# Altium schematic sheet 2_MIC.SchDoc
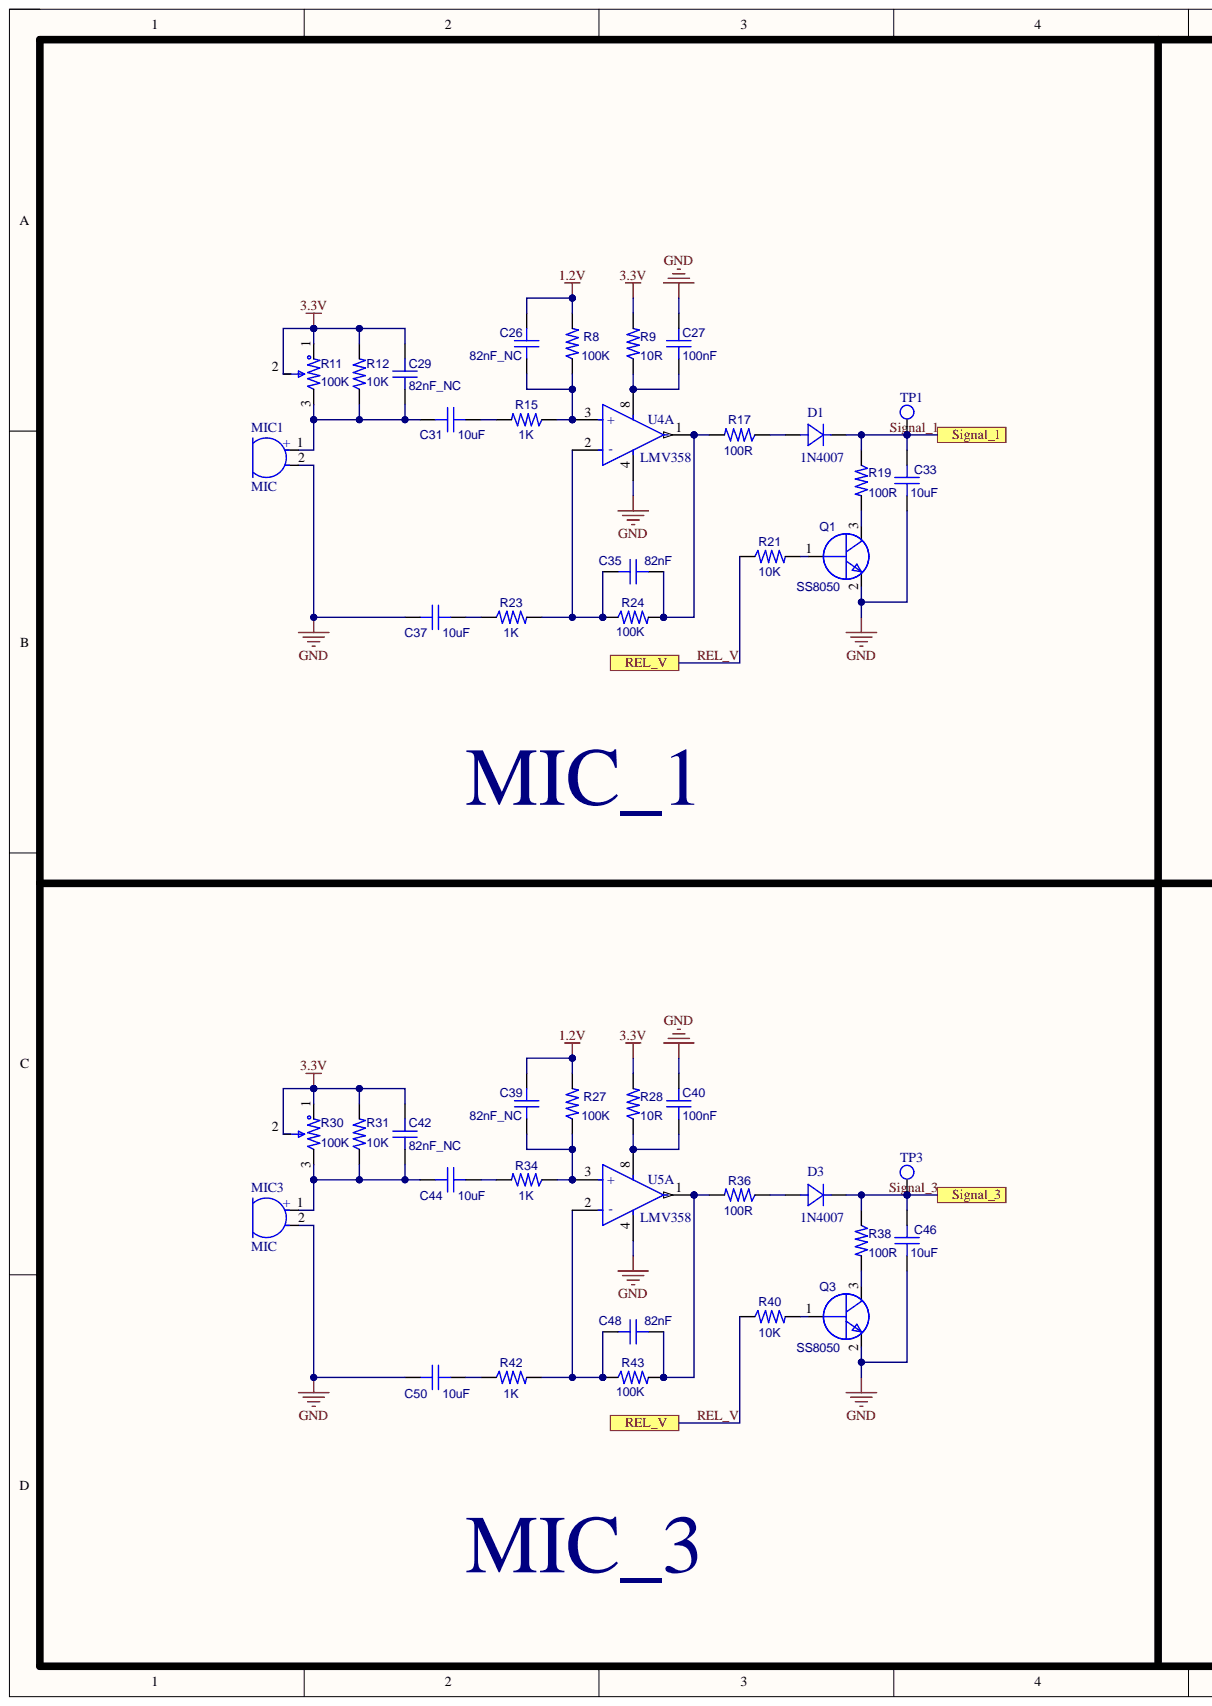
[diagram p0: 2_MIC.SchDoc, left]
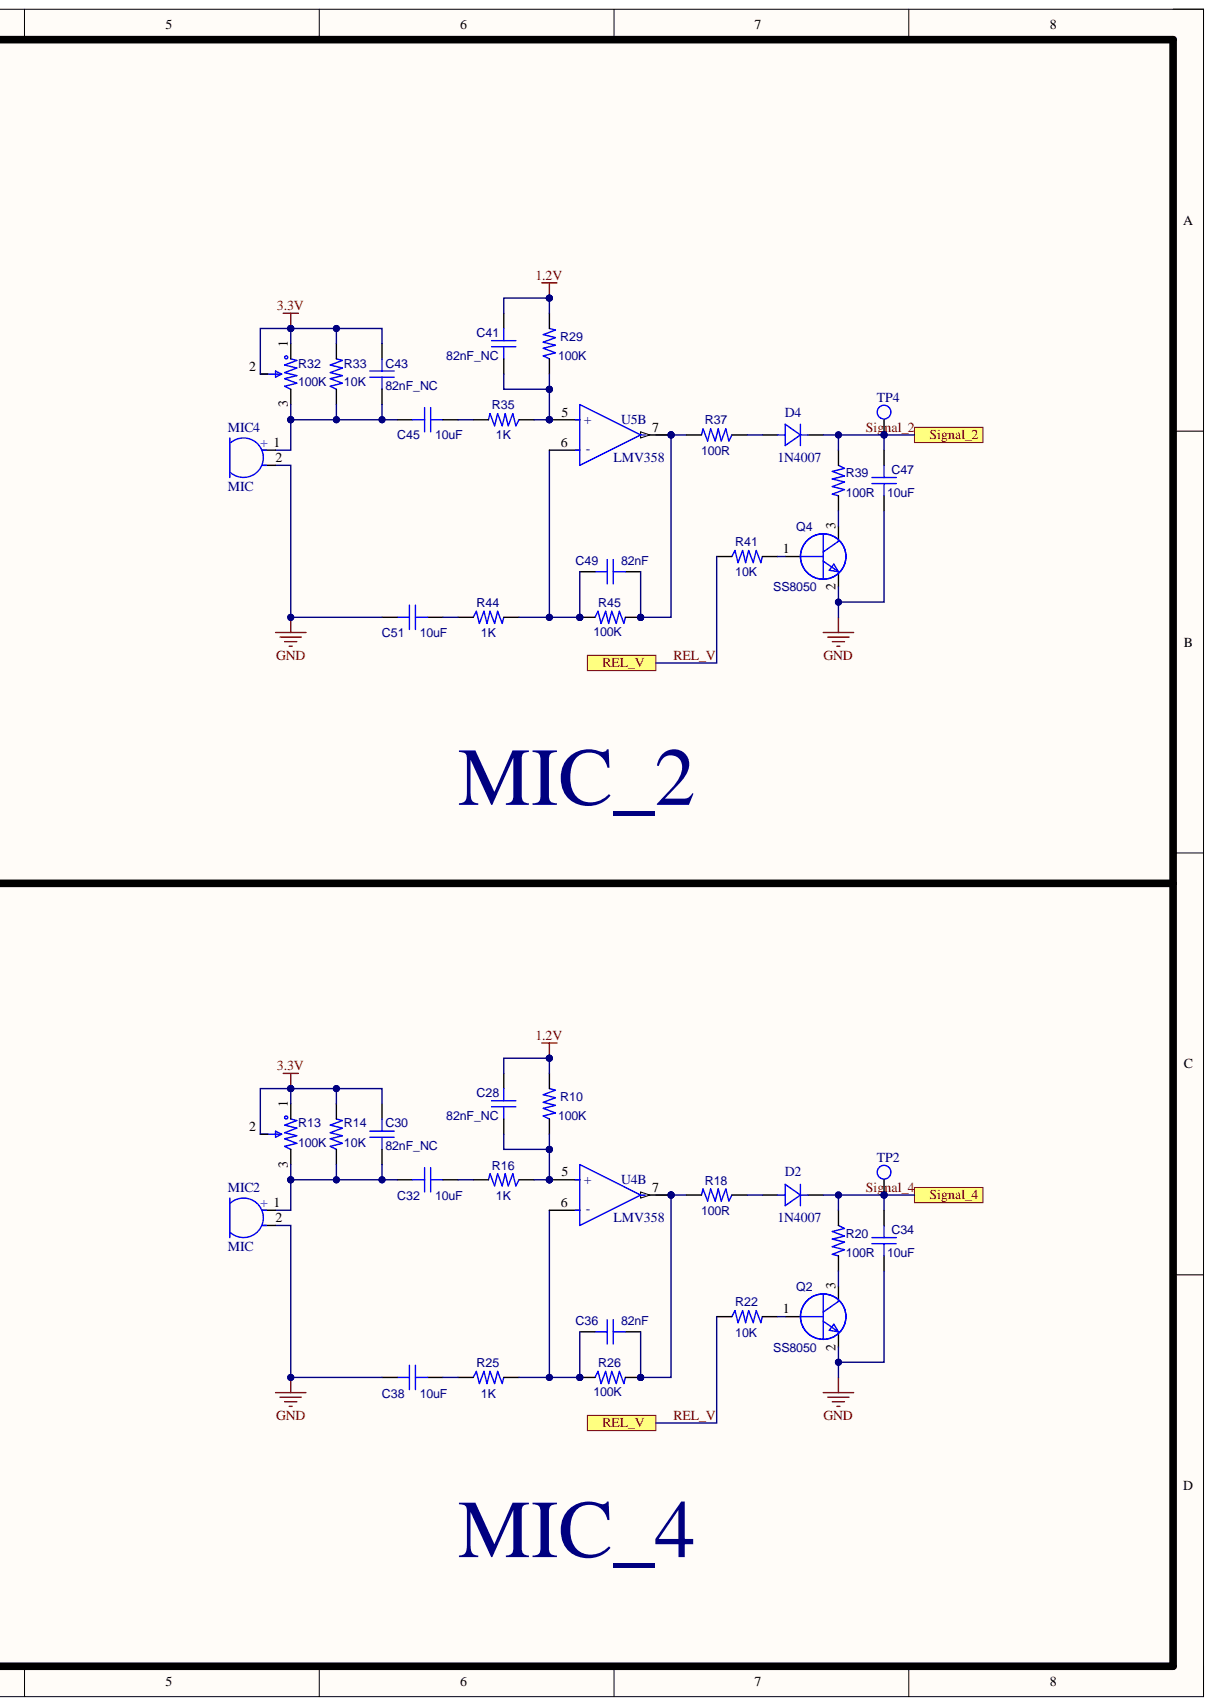
[diagram p1: 2_MIC.SchDoc, right]

## Parts
C26  82nF_NC  [CAP-SMD-0402]
C27  100nF  [CAP-SMD-0402]
C28  82nF_NC  [CAP-SMD-0402]
C29  82nF_NC  [CAP-SMD-0402]
C30  82nF_NC  [CAP-SMD-0402]
C31  10uF  [CAP-SMD-0603]
C32  10uF  [CAP-SMD-0603]
C33  10uF  [CAP-SMD-0603]
C34  10uF  [CAP-SMD-0603]
C35  82nF  [CAP-SMD-0402]
C36  82nF  [CAP-SMD-0402]
C37  10uF  [CAP-SMD-0603]
C38  10uF  [CAP-SMD-0603]
C39  82nF_NC  [CAP-SMD-0402]
C40  100nF  [CAP-SMD-0402]
C41  82nF_NC  [CAP-SMD-0402]
C42  82nF_NC  [CAP-SMD-0402]
C43  82nF_NC  [CAP-SMD-0402]
C44  10uF  [CAP-SMD-0603]
C45  10uF  [CAP-SMD-0603]
C46  10uF  [CAP-SMD-0603]
C47  10uF  [CAP-SMD-0603]
C48  82nF  [CAP-SMD-0402]
C49  82nF  [CAP-SMD-0402]
C50  10uF  [CAP-SMD-0603]
C51  10uF  [CAP-SMD-0603]
D1  1N4007  [SOD-123-P3.4]
D2  1N4007  [SOD-123-P3.4]
D3  1N4007  [SOD-123-P3.4]
D4  1N4007  [SOD-123-P3.4]
MIC1  MIC  [CONN-TH-1X2P-P2.54_2]
MIC2  MIC  [CONN-TH-1X2P-P2.54_2]
MIC3  MIC  [CONN-TH-1X2P-P2.54_2]
MIC4  MIC  [CONN-TH-1X2P-P2.54_2]
Q1  SS8050  [SOT-23-3-LP2.28-P1.91]
Q2  SS8050  [SOT-23-3-LP2.28-P1.91]
Q3  SS8050  [SOT-23-3-LP2.28-P1.91]
Q4  SS8050  [SOT-23-3-LP2.28-P1.91]
R8  100K  [RES-SMD-0402]
R9  10R  [RES-SMD-0402]
R10  100K  [RES-SMD-0402]
R11  100K  [RES-TH-ADJ-3396W]
R12  10K  Resistance (Ohms): 100K  [RES-SMD-0402]
R13  100K  [RES-TH-ADJ-3396W]
R14  10K  Resistance (Ohms): 100K  [RES-SMD-0402]
R15  1K  [RES-SMD-0402]
R16  1K  [RES-SMD-0402]
R17  100R  [RES-SMD-0402]
R18  100R  [RES-SMD-0402]
R19  100R  [RES-SMD-0402]
R20  100R  [RES-SMD-0402]
R21  10K  [RES-SMD-0402]
R22  10K  [RES-SMD-0402]
R23  1K  [RES-SMD-0402]
R24  100K  [RES-SMD-0402]
R25  1K  [RES-SMD-0402]
R26  100K  [RES-SMD-0402]
R27  100K  [RES-SMD-0402]
R28  10R  [RES-SMD-0402]
R29  100K  [RES-SMD-0402]
R30  100K  [RES-TH-ADJ-3396W]
R31  10K  Resistance (Ohms): 100K  [RES-SMD-0402]
R32  100K  [RES-TH-ADJ-3396W]
R33  10K  Resistance (Ohms): 100K  [RES-SMD-0402]
R34  1K  [RES-SMD-0402]
R35  1K  [RES-SMD-0402]
R36  100R  [RES-SMD-0402]
R37  100R  [RES-SMD-0402]
R38  100R  [RES-SMD-0402]
R39  100R  [RES-SMD-0402]
R40  10K  [RES-SMD-0402]
R41  10K  [RES-SMD-0402]
R42  1K  [RES-SMD-0402]
R43  100K  [RES-SMD-0402]
R44  1K  [RES-SMD-0402]
R45  100K  [RES-SMD-0402]
TP1  TP  [TP]
TP2  TP  [TP]
TP3  TP  [TP]
TP4  TP  [TP]
U4  LMV358  [SOIC-8-LP5.5-P1.27]  (parts A,B)
U5  LMV358  [SOIC-8-LP5.5-P1.27]  (parts A,B)

## Ports
REL_V, Signal_1, Signal_2, Signal_3, Signal_4

## Nets
1.2V: C26.2 C28.2 C39.2 C41.2 R8.2 R10.2 R27.2 R29.2
3.3V: C29.2 C30.2 C42.2 C43.2 R9.2 R11.1 R11.2 R12.2 R13.1 R13.2 R14.2 R28.2 R30.1 R30.2 R31.2 R32.1 R32.2 R33.2
GND: C27.1 C33.2 C34.2 C37.1 C38.1 C40.1 C46.2 C47.2 C50.1 C51.1 MIC1.2 MIC2.2 MIC3.2 MIC4.2 Q1.2 Q2.2 Q3.2 Q4.2 U4.4 U5.4
REL_V: R21.1 R22.1 R40.1 R41.1 ; port REL_V
Signal_1: C33.1 D1.2 R19.1 TP1.1 ; port Signal_1
Signal_2: C47.1 D4.2 R39.1 TP4.1 ; port Signal_2
Signal_3: C46.1 D3.2 R38.1 TP3.1 ; port Signal_3
Signal_4: C34.1 D2.2 R20.1 TP2.1 ; port Signal_4
NetC26_1: C26.1 R8.1 R15.1 U4.3(+)
NetC27_2: C27.2 R9.1 U4.8
NetC28_1: C28.1 R10.1 R16.1 U4.5(+)
NetC29_1: C29.1 C31.2 MIC1.1 R11.3 R12.1
NetC30_1: C30.1 C32.2 MIC2.1 R13.3 R14.1
NetC31_1: C31.1 R15.2
NetC32_1: C32.1 R16.2
NetC35_1: C35.1 R23.2 R24.1 U4.2(-)
NetC35_2: C35.2 R17.2 R24.2 U4.1
NetC36_1: C36.1 R25.2 R26.1 U4.6(-)
NetC36_2: C36.2 R18.2 R26.2 U4.7
NetC37_2: C37.2 R23.1
NetC38_2: C38.2 R25.1
NetC39_1: C39.1 R27.1 R34.1 U5.3(+)
NetC40_2: C40.2 R28.1 U5.8
NetC41_1: C41.1 R29.1 R35.1 U5.5(+)
NetC42_1: C42.1 C44.2 MIC3.1 R30.3 R31.1
NetC43_1: C43.1 C45.2 MIC4.1 R32.3 R33.1
NetC44_1: C44.1 R34.2
NetC45_1: C45.1 R35.2
NetC48_1: C48.1 R42.2 R43.1 U5.2(-)
NetC48_2: C48.2 R36.2 R43.2 U5.1
NetC49_1: C49.1 R44.2 R45.1 U5.6(-)
NetC49_2: C49.2 R37.2 R45.2 U5.7
NetC50_2: C50.2 R42.1
NetC51_2: C51.2 R44.1
NetD1_1: D1.1 R17.1
NetD2_1: D2.1 R18.1
NetD3_1: D3.1 R36.1
NetD4_1: D4.1 R37.1
NetQ1_1: Q1.1 R21.2
NetQ1_3: Q1.3 R19.2
NetQ2_1: Q2.1 R22.2
NetQ2_3: Q2.3 R20.2
NetQ3_1: Q3.1 R40.2
NetQ3_3: Q3.3 R38.2
NetQ4_1: Q4.1 R41.2
NetQ4_3: Q4.3 R39.2

## Library data of the parts
C26-C51 = CAP: 贴片电容; BOM_Manufacturer: SAMSUNG; BOM_Manufacturer Part: CL03A104KQ3NNNC; Contributor: LCSC; nameAlias: Capacitance; BOM_JLCPCB Part Class: Extended Part; BOM_Supplier: LCSC; BOM_Supplier Part: C160831; Component Kind: Standard; spicePre: C; spiceSymbolName: CL03A104KQ3NNNC; Library Name: Pan-Int-2022-08-06.IntLib
D1-D4 = Diode: 二极管1N4148W; Manufacturer: PSI(宝力芯); Add into BOM: yes; Average Rectified Current (Io): 150mA; Forward Voltage (Vf@If): 1.25V@150mA; Power Dissipation: 350mW; Reverse Leakage Current: 1A@75V; Reverse Recovery Time (trr): 4ns; Reverse Voltage (Vr): 100V; Symbol: 1N4148W_C2840890; Component Kind: Standard; Convert to PCB: yes; Datasheet: https://atta.szlcsc.com/upload/public/pdf/source/20210709/C2840890_3AF002B6505A4091F26B54E36362ABEF.pdf; Device: 1N4148W; Diode Configuration: -; JLCPCB Part Class: Extended Part; LCSC Part Name: 100V 150mA 4ns; Manufacturer Part: 1N4148W; Operating Temperature: +150@(Tj); Supplier: LCSC; Supplier Footprint: SOD-123; Supplier Part: C2840890; Library Name: Pan-Int-2022-08-06.IntLib
MIC1-MIC4 = MIC: 咪头MIC COND ANALOG OMNI -38DB; Component Kind: Standard; Library Name: Pan-Int-20221102.IntLib
Q1-Q4 = NPN-SOT-23-3: 贴片NPN三极管; BOM_Manufacturer: YFW佑风微; BOM_Manufacturer Part: S9013; Contributor: LCSC; BOM_JLCPCB Part Class: Extended Part; BOM_Supplier: LCSC; BOM_Supplier Part: C2898668; Component Kind: Standard; spicePre: Q; spiceSymbolName: S9013_C2898668; Library Name: Pan-Int-2022-08-06.IntLib
R8 R10 R12 R14 R24 R26 R27 R29 R31 R33 R43 R45 = RES: 贴片电阻; BOM_Manufacturer: Chengdu Guosheng Tech; BOM_Manufacturer Part: 3362P-1-104; Contributor: LCSC; nameAlias: Resistance; BOM_JLCPCB Part Class: Extended Part; BOM_Supplier: LCSC; BOM_Supplier Part: C118966; Component Kind: Standard; Resistance(Ohms): 100K; spicePre: R; spiceSymbolName: 3362P-1-104_C118966; Library Name: Pan-Int-2022-08-06.IntLib
  R8 R10 R24 R26 R27 R29 R43 R45: Resistance (Ohms): 100K
R9 R15-R23 R25 R28 R34-R42 R44 = RES: 贴片电阻; BOM_Manufacturer: Chengdu Guosheng Tech; BOM_Manufacturer Part: 3362P-1-104; Contributor: LCSC; nameAlias: Resistance; Resistance (Ohms): 100K; BOM_JLCPCB Part Class: Extended Part; BOM_Supplier: LCSC; BOM_Supplier Part: C118966; Component Kind: Standard; Resistance(Ohms): 100K; spicePre: R; spiceSymbolName: 3362P-1-104_C118966; Library Name: Pan-Int-2022-08-06.IntLib
R11 R13 R30 R32 = RES-ADJ: 可调电阻,电位器; BOM_Manufacturer: Chengdu Guosheng Tech; BOM_Manufacturer Part: 3362P-1-104; Contributor: LCSC; nameAlias: Resistance; BOM_JLCPCB Part Class: Extended Part; BOM_Supplier: LCSC; BOM_Supplier Part: C118966; Component Kind: Standard; Resistance (Ohms): 100K; Resistance(Ohms): 100K; spicePre: R; spiceSymbolName: 3362P-1-104_C118966; Library Name: Pan-Int-2022-08-06.IntLib
TP1-TP4 = TP: TP测试点; Component Kind: Standard; Library Name: Pan-Int-20221102.IntLib
U4 U5 = LMV358: 运放IC OPAMP GP 2 CIRCUIT 8SOIC; Component Kind: Standard; Library Name: Pan-lib.IntLib
